# Altium schematic sheet CAN misc.SchDoc("CAN misc")
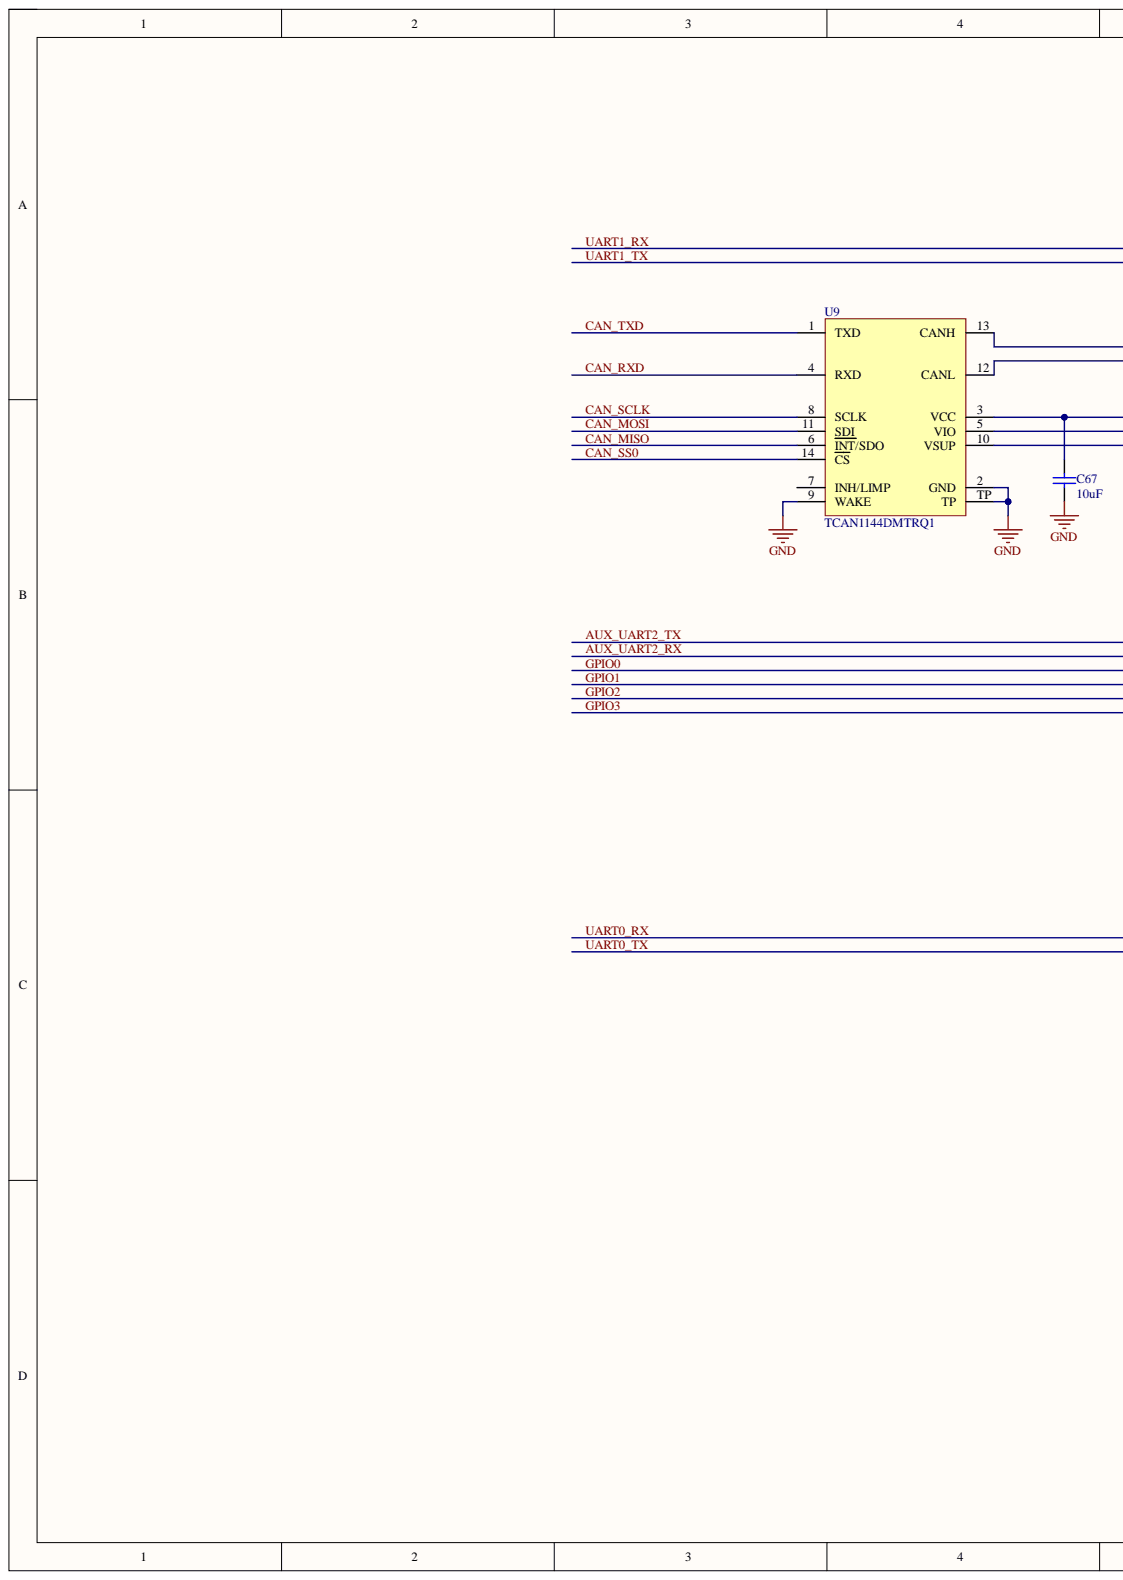
[diagram p0: CAN misc.SchDoc, left]
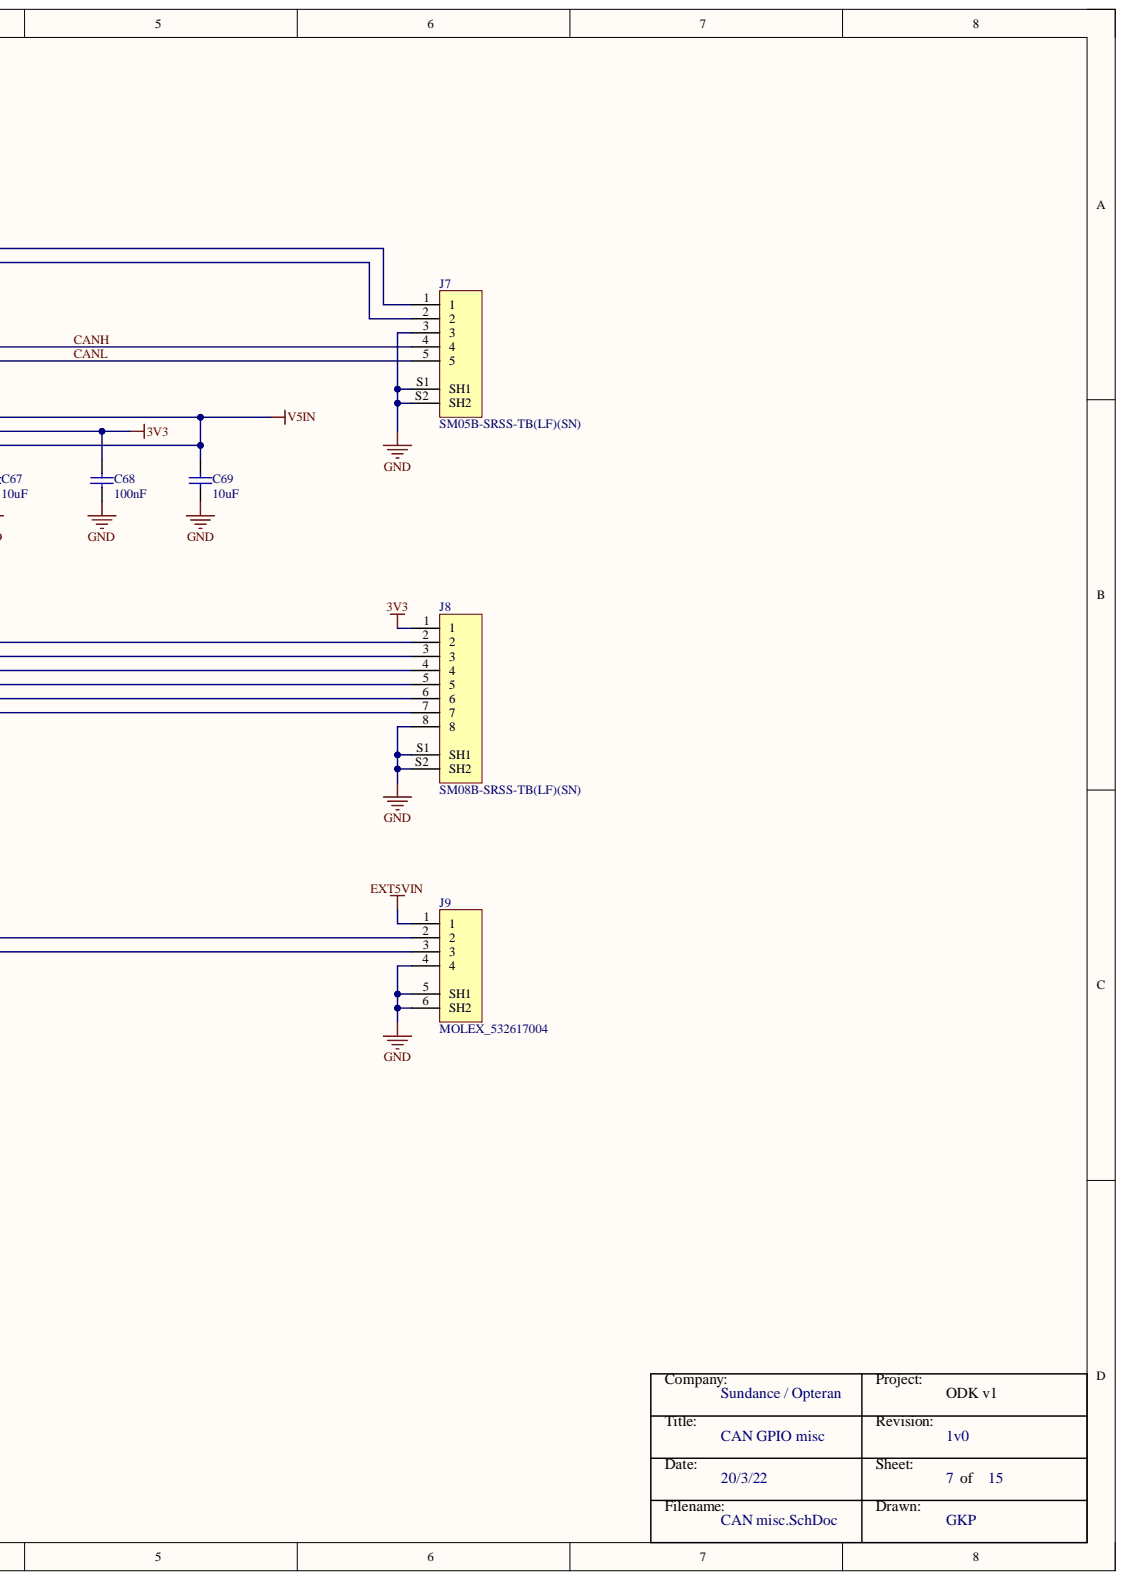
[diagram p1: CAN misc.SchDoc, right]

## Parts
C67  10uF  [CAP0402]
C68  100nF  [0201panERJ]
C69  10uF  [CAP0402]
J7  SM05B-SRSS-TB(LF)(SN)  [JST_SM05B-SRSS-TB(LF)(SN)]
J8  SM08B-SRSS-TB(LF)(SN)  [JST_SM08B-SRSS-TB(LF)(SN)]
J9  MOLEX_532617004  [CON_532617004]
U9  TCAN1144DMTRQ1  [VSON14 DMT]

## Nets
3V3: C68.2 J8.1 U9.5(VIO)
EXT5VIN: J9.1
GND: C67.2 C68.1 C69.2 J7.3 J7.S1(SH1) J7.S2(SH2) J8.8 J8.S1(SH1) J8.S2(SH2) J9.4 J9.5(SH1) J9.6(SH2) U9.2(GND) U9.9(WAKE) U9.TP
V5IN: C67.1 C69.1 U9.3(VCC) U9.10(VSUP)
AUX_UART2_RX: J8.3
AUX_UART2_TX: J8.2
CAN_MISO: U9.6(INT/SDO)
CAN_MOSI: U9.11(SDI)
CAN_RXD: U9.4(RXD)
CAN_SCLK: U9.8(SCLK)
CAN_SS0: U9.14(CS)
CAN_TXD: U9.1(TXD)
CANH: J7.4 U9.13(CANH)
CANL: J7.5 U9.12(CANL)
GPIO0: J8.4
GPIO1: J8.5
GPIO2: J8.6
GPIO3: J8.7
UART0_RX: J9.2
UART0_TX: J9.3
UART1_RX: J7.1
UART1_TX: J7.2

Not connected: U9 7(INH/LIMP)

## Library data of the parts
C67 C69 = Cap0402: 10uF 0402 10V X5R; Case-EIA: 0402; Component Kind: Standard; Library Name: Schematic Components.SchLib; Max Thickness: 0.6 mm; Technology: SMT
C68 = Cap0201: 100nF 0201 16V X5R; Case-EIA: 0402; Component Kind: Standard; Library Name: Schematic Components.SchLib; Max Thickness: 0.6 mm; Technology: SMT
J7 = JST SM05B-SRSS-TB(LF)(SN): Connector Header Surface Mount, Right Angle 5 position 0.039" (1.00mm); Manufacturer 1: JST Sales America Inc.; Component Kind: Standard; Library Name: Schematic Components.SchLib; Manufacturer Part Number 1: SM05B-SRSS-TB(LF)(SN); Supplier 1: Farnell; Supplier Part Number 1: 1679121
J8 = JST SM08B-SRSS-TB(LF)(SN): Connector Header Surface Mount, Right Angle 8 position 0.039" (1.00mm); Manufacturer 1: JST Sales America Inc.; Component Kind: Standard; Library Name: Schematic Components.SchLib; Manufacturer Part Number 1: SM08B-SRSS-TB(LF)(SN); Supplier 1: Farnell; Supplier Part Number 1: 1679124
J9 = MOLEX_532617004: PicoBlade, Connector Header Surface Mount, Right Angle 4 position 0.049" (1.25mm); Manufacturer 1: Molex; Manufacturer Part Number 1: 0532617004; Component Kind: Standard; Library Name: Schematic Components.SchLib; Supplier 1: Farnell; Supplier Part Number 1: 2990521
U9 = TCAN114xDMTRQ1: 1/1 Transceiver Half CAN 14-VSON (3x4.5); Manufacturer 1: Texas Instruments; Component Kind: Standard; Library Name: Schematic Components.SchLib; Manufacturer Part Number 1: TCAN1144DMTRQ1; Supplier 1: Digi-Key; Supplier Part Number 1: 296-TCAN1144DMTRQ1TR-ND
